# Altium schematic sheet FEZ-Bit-Connectors.SchDoc(FEZ-Bit-Connectors)
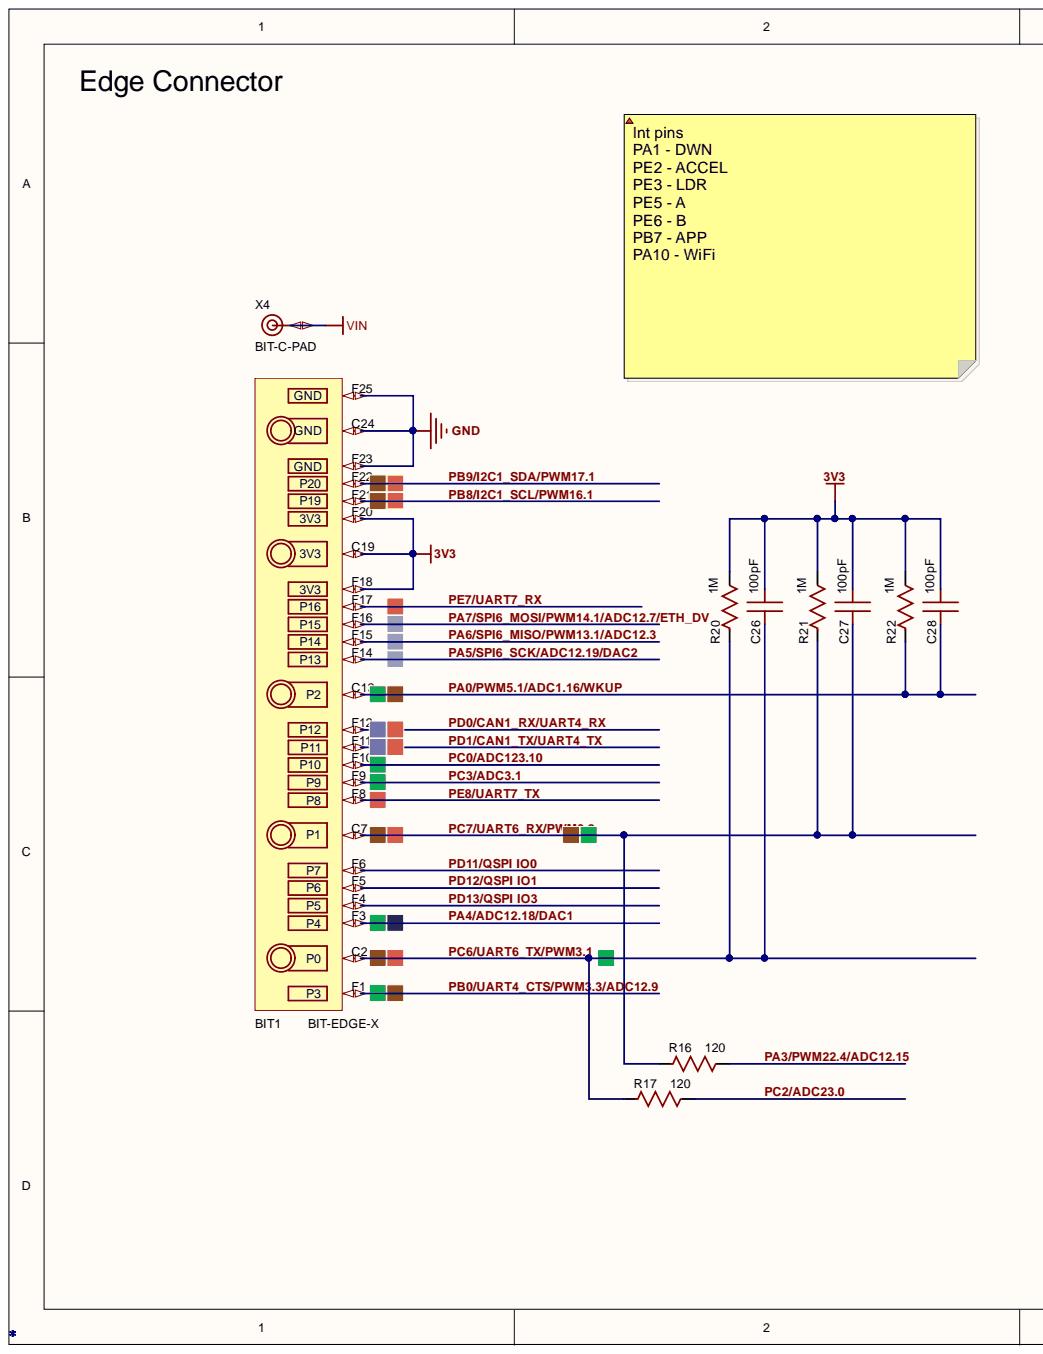
[diagram p0: FEZ-Bit-Connectors.SchDoc, left]
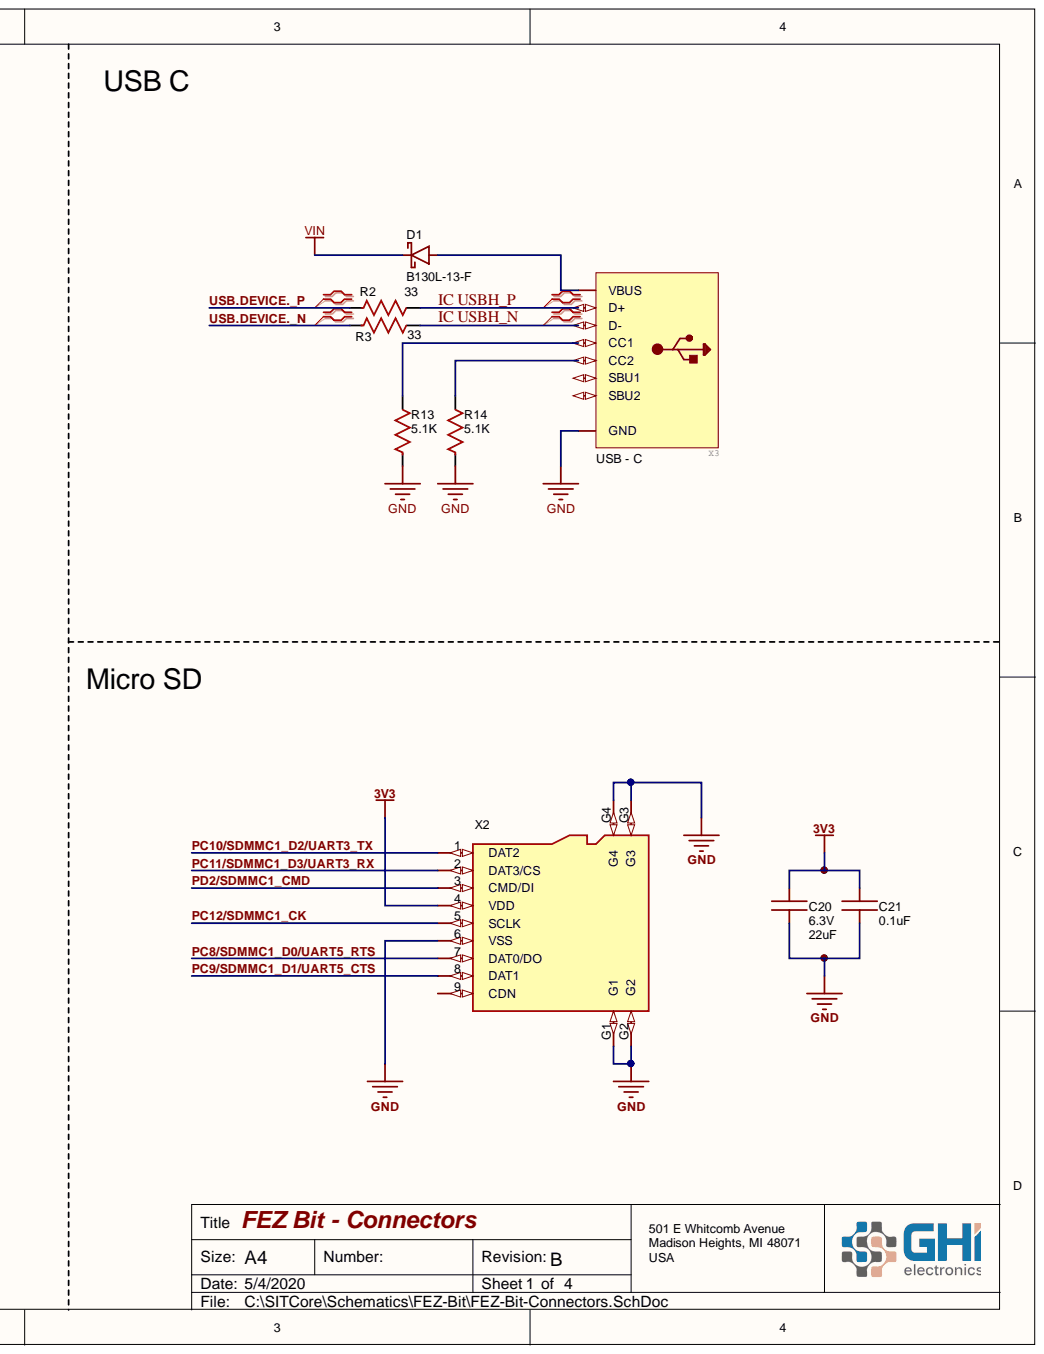
[diagram p1: FEZ-Bit-Connectors.SchDoc, right]

## Parts
BIT1  BIT-EDGE-X  [BIT-EDGE-X]
C20  value 22uF  Voltage: 6.3V  [C0805]  (hidden comment: 0805 Cap 22uF)
C21  value 0.1uF  [C0603 (1608 Metric)]  (hidden comment: 0603 Cap 0.1uF)
C26  value 100pF  [C0603 (Metric 1608)]  (hidden comment: 0603 Cap)
C27  value 100pF  [C0603 (Metric 1608)]  (hidden comment: 0603 Cap)
C28  value 100pF  [C0603 (Metric 1608)]  (hidden comment: 0603 Cap)
D1  B130L-13-F  [SMA]
R2  value 33  [R0402]  (hidden comment: 0402 Res 33)
R3  value 33  [R0402]  (hidden comment: 0402 Res 33)
R13  value 5.1K  [R0402]  (hidden comment: 0402 Res 5.1K)
R14  value 5.1K  [R0402]  (hidden comment: 0402 Res 5.1K)
R16  value 120  [R0603 (1608 Metric)]  (hidden comment: 0603 120)
R17  value 120  [R0603 (1608 Metric)]  (hidden comment: 0603 120)
R20  value 1M  [0603 RES (1608 Metric)]  (hidden comment: 0603 R)
R21  value 1M  [0603 RES (1608 Metric)]  (hidden comment: 0603 R)
R22  value 1M  [0603 RES (1608 Metric)]  (hidden comment: 0603 R)
X2  MICROSD  [MICROSD]  (value not drawn)
X3  (no value)  [GCT_USB4105_revA]
X4  BIT-C-PAD  [BIT-C-PAD]

## Nets
3V3: BIT1.C19(3V3) BIT1.F18(3V3) BIT1.F20(3V3) C20.2 C21.2 C26.1 C27.1 C28.1 R20.1 R21.1 R22.1 X2.4(VDD)
GND: BIT1.C24(GND) BIT1.F23(GND) BIT1.F25(GND) C20.1 C21.1 R13.1 R14.1 X2.6(VSS) X2.G1 X2.G2 X2.G3 X2.G4 X3.GND
VIN: D1.K X4.1
IC USBH_N: R3.2 X3.A7B7(D-)
IC USBH_P: R2.2 X3.A6B6(D+)
PA0/PWM5.1/ADC1.16/WKUP: BIT1.C13(P2) C28.2 R22.2
PA3/PWM22.4/ADC12.15: R16.2
PA4/ADC12.18/DAC1: BIT1.F3(P4)
PA5/SPI6_SCK/ADC12.19/DAC2: BIT1.F14(P13)
PA6/SPI6_MISO/PWM13.1/ADC12.3: BIT1.F15(P14)
PA7/SPI6_MOSI/PWM14.1/ADC12.7/ETH_DV: BIT1.F16(P15)
PB0/UART4_CTS/PWM3.3/ADC12.9: BIT1.F1(P3)
PB8/I2C1_SCL/PWM16.1: BIT1.F21(P19)
PB9/I2C1_SDA/PWM17.1: BIT1.F22(P20)
PC0/ADC123.10: BIT1.F10(P10)
PC2/ADC23.0: R17.2
PC3/ADC3.1: BIT1.F9(P9)
PC6/UART6_TX/PWM3.1: BIT1.C2(P0) C26.2 R17.1 R20.2
PC7/UART6_RX/PWM3.2: BIT1.C7(P1) C27.2 R16.1 R21.2
PC8/SDMMC1_D0/UART5_RTS: X2.7(DAT0/DO)
PC9/SDMMC1_D1/UART5_CTS: X2.8(DAT1)
PC10/SDMMC1_D2/UART3_TX: X2.1(DAT2)
PC11/SDMMC1_D3/UART3_RX: X2.2(DAT3/CS)
PC12/SDMMC1_CK: X2.5(SCLK)
PD0/CAN1_RX/UART4_RX: BIT1.F12(P12)
PD1/CAN1_TX/UART4_TX: BIT1.F11(P11)
PD2/SDMMC1_CMD: X2.3(CMD/DI)
PD11/QSPI IO0: BIT1.F6(P7)
PD12/QSPI IO1: BIT1.F5(P6)
PD13/QSPI IO3: BIT1.F4(P5)
PE7/UART7_RX: BIT1.F17(P16)
PE8/UART7_TX: BIT1.F8(P8)
USB.DEVICE._N: R3.1
USB.DEVICE._P: R2.1
NetD1_A: D1.A X3.A4B9(VBUS)
NetR13_2: R13.2 X3.A5(CC1)
NetR14_2: R14.2 X3.B5(CC2)

Not connected: X2 9(CDN); X3 A8(SBU1) B8(SBU2)

## Library data of the parts
BIT1 = BIT-EDGE-X: BIT is the complete dimension with the edge. BIT-EDGE is only the edge connector of the BIT. BIT-EDGE-X is a simplified pad configuration for the BIT.; GateName_1: G$1; SymbolName_1: BIT; DeviceSetName: BIT; DeviceName: -EDGE-X; Component Kind: Standard; LibraryName: GHI-Solutions; Library Name: GHI.SchLib
C20 = 0805 Cap 22uF: 22uF ±20% 6.3V X5R 0805 Multilayer Ceramic Capacitors MLCC - SMD/SMT RoHS; Mfr.Part #: CL21A226MQCLRNC; Tolerance: ±20%; Package: 0805; LCSC Part #: C307530; Component Kind: Standard; Category: Ceramic; Capacitance: 22uF; Library Name: GHI-Passives.SchLib
C21 = 0603 Cap 0.1uF: 0.1uF ±5% 50V X7R 0603 Multilayer Ceramic Capacitors MLCC - SMD/SMT RoHS; Mfr.Part #: CL10B104JB8NNNC; Voltage: 50V; Tolerance: ±5%; Package: 0603; LCSC Part #: C24452; Digikey: 1276-1033-1-ND; Component Kind: Standard; Category: Ceramic; Capacitance: 0.1uF; Library Name: GHI-Passives.SchLib
C26-C28 = 0603 Capacitor (Metric 1608): Capacitor 0603 Generic; Voltage: 50V; Capacitor Class: Ceramic; Package: 0603; Component Type: Capacitor; Component Kind: Standard; Capacitance Tolerance: N/A; Capacitance: 100pF; Library Name: GHI.SchLib
D1 = B130L-13-F 1A Schottky SMA: 30V 1A 410mV @ 1A SMA(DO-214AC) Schottky Barrier Diodes (SBD) RoHS; Manufacturer: Diodes Incorporated; GHI Part Number: EPC-DB130L-13; Value: B130L-13-F; Package: SMA(DO-214AC); Mfr.Part #: B130L-13-F; Library Name: GHI.SchLib; LCSC Part #: C134425; Component Type: Diode; Component Kind: Standard
R2 R3 = 0402 Res 33: 33 ±1% 1/16W ±100ppm/℃ 0402 Chip Resistor - Surface Mount RoHS; Mfr.Part #: RC0402FR-0733RL; Manufacturer: YAGEO; Voltage Rating: *V; Resistance Tolerance: ±1%; Resistance: 33; Power Rating: 1/16W; Package: 0402; LCSC Part #: C138002; Component Kind: Standard; Library Name: GHI-Passives.SchLib
R13 R14 = 0402 Res 5.1K: 5.1K ±1% 1/16W ±100ppm/℃ 0402 Chip Resistor - Surface Mount RoHS; Mfr.Part #: RC0402FR-075K1L; Manufacturer: YAGEO; Voltage Rating: *V; Resistance Tolerance: ±1%; Resistance: 5.1K; Power Rating: 1/16W; Package: 0402; LCSC Part #: C105872; Component Kind: Standard; Library Name: GHI-Passives.SchLib
R16 R17 = 0603 Res 120: 120 ±1% 1/10W ±100ppm/℃ 0603 Chip Resistor - Surface Mount RoHS; Mfr.Part #: RC0603FR-07120RL; Manufacturer: YAGEO; Voltage Rating: *V; Resistance Tolerance: ±1%; Resistance: 120; Power Rating: 1/10W; Package: 0603; LCSC Part #: C114640; Component Kind: Standard; Library Name: GHI-Passives.SchLib
R20-R22 = 0603 Resistor: Voltage Rating: *V; Resistance Tolerance: *%; Resistance: 1M; Package: 0603; Component Kind: Standard; Library Name: GHI.SchLib
X3 = USB - C: USB - C (Type - C) USB 2.0 Receptacle Connector 24 (16+8 Dummy) Position Surface Mount, Right Angle; Through Hole; Manufacturer: GCT; Value: USB4105-GF-A; Mfr.Part #: USB4105-GF-A; LCSC Part #: C319148; Digi-Key Part Number: 2073-USB4105-GF-ATR-ND; Component Kind: Standard; : USB - C; Library Name: GHI-Connectors.SchLib
X4 = BIT-C-PAD: GateName_1: G$1; SymbolName_1: BIT-C-PAD; DeviceSetName: BIT-C-PAD; Component Kind: Standard; LibraryName: GHI-SpecialPads; Library Name: GHI-Connectors.SchLib
X2 = MICROSD: Value: MicroSD; PartNo: MicroSD; Component Kind: Standard; Library Name: GHI.SchLib
